# Altium schematic sheet INTERFACE.SchDoc(INTERFACE)
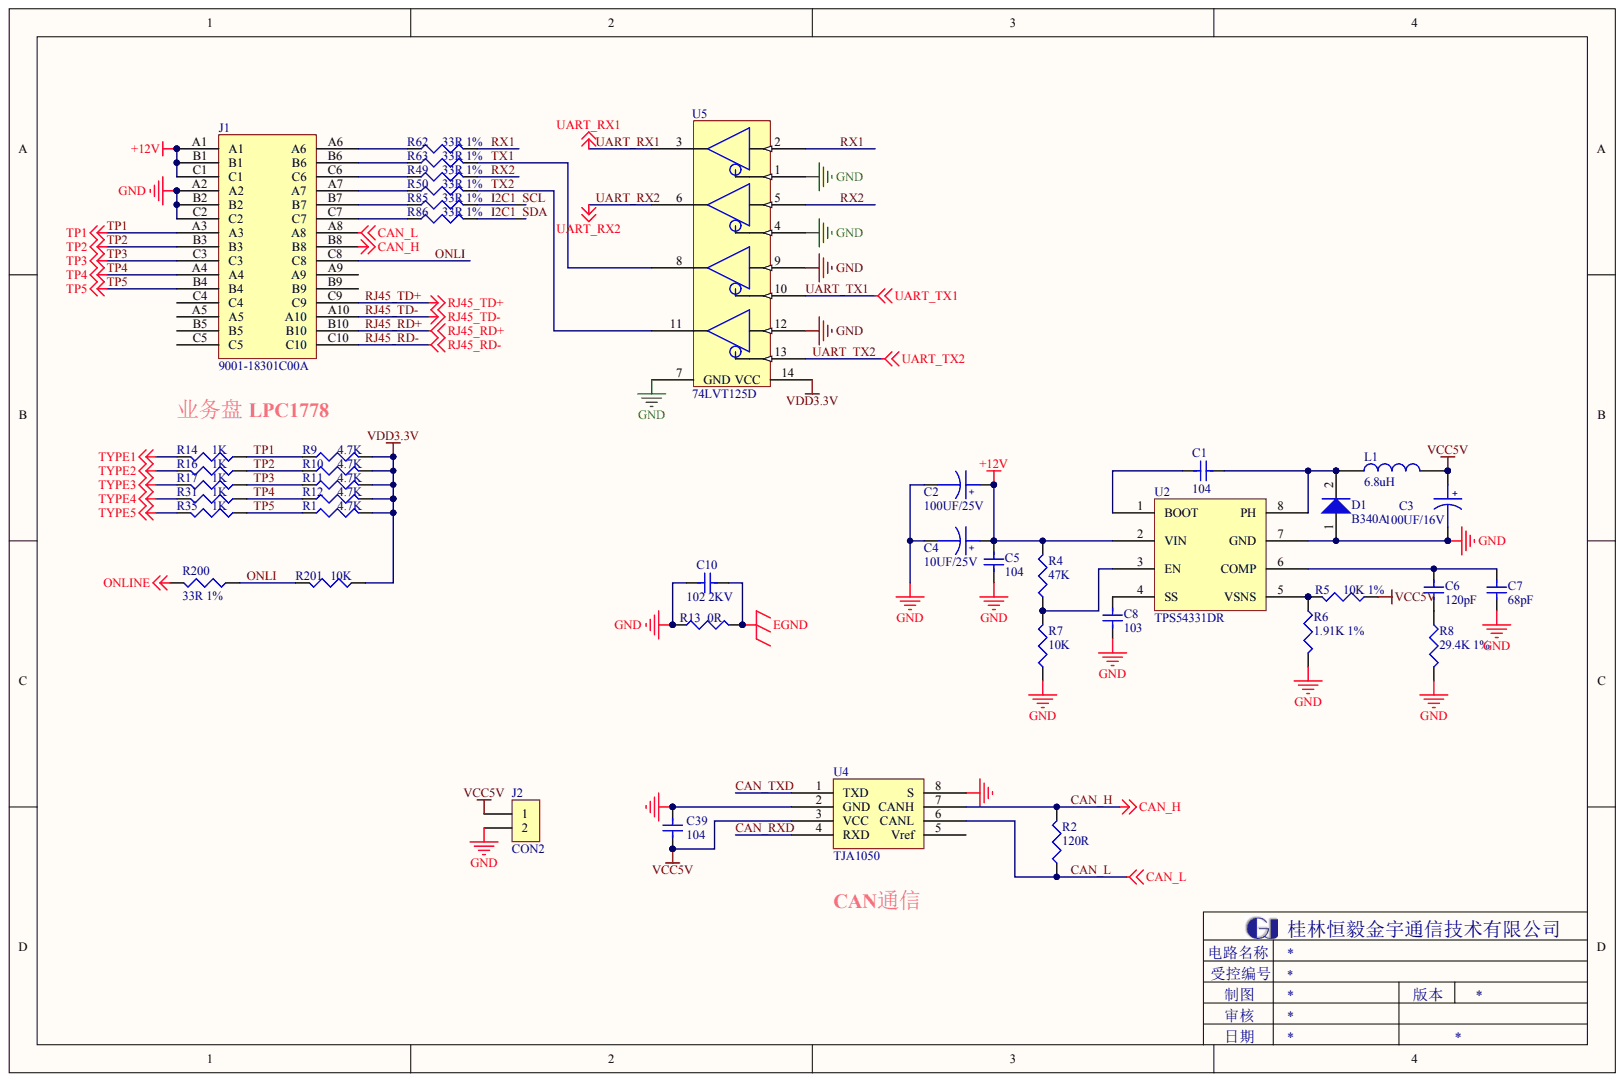
[diagram p0: INTERFACE.SchDoc]

## Parts
C1  104
C2  100UF/25V
C3  100UF/16V
C4  10UF/25V
C5  104
C6  120pF
C7  68pF
C8  103
C10  102 2KV
C39  104
D1  B340A
J1  9001-18301C00A
J2  CON2
L1  6.8uH
R1  4.7K
R2  120R
R4  47K
R5  10K 1%
R6  1.91K 1%
R7  10K
R8  29.4K 1%
R9  4.7K
R10  4.7K
R11  4.7K
R12  4.7K
R13  0R
R14  1K
R16  1K
R17  1K
R31  1K
R35  1K
R49  33R 1%
R50  33R 1%
R62  33R 1%
R63  33R 1%
R85  33R 1%
R86  33R 1%
R200  33R 1%
R201  10K
U2  TPS54331DR
U4  TJA1050
U5  74LVT125D

## Nets
+12V: C2.1 C4.1 C5.2 J1.A1 J1.B1 J1.C1 R4.2 U2.2(VIN)
EGND: C10.2 R13.2
GND: C2.2 C3.2 C4.2 C5.1 C7.1 C8.1 C10.1 C39.1 D1.1 J1.A2 J1.B2 J1.C2 J2.2 R6.2 R7.1 R8.2 R13.1 U2.7(GND) U4.2(GND) U4.8(S) U5.1 U5.4 U5.7(GND) U5.9 U5.12
ONLINE: R200.2
TYPE1: R14.2
TYPE2: R16.2
TYPE3: R17.2
TYPE4: R31.2
TYPE5: R35.2
VCC5V: C3.1 C39.2 J2.1 L1.2 R5.1 U4.3(VCC)
VDD3.3V: R1.2 R9.2 R10.2 R11.2 R12.2 R201.1 U5.14(VCC)
CAN_H: J1.B8 R2.2 U4.7(CANH)
CAN_L: J1.A8 R2.1 U4.6(CANL)
CAN_RXD: U4.4(RXD)
CAN_TXD: U4.1(TXD)
I2C1_SCL: R85.2
I2C1_SDA: R86.2
ONLI: J1.C8 R200.1 R201.2
RJ45_RD+: J1.B10
RJ45_RD-: J1.C10
RJ45_TD+: J1.C9
RJ45_TD-: J1.A10
RX1: R62.2 U5.2
RX2: R49.2 U5.5
TP1: J1.A3 R9.1 R14.1
TP2: J1.B3 R10.1 R16.1
TP3: J1.C3 R11.1 R17.1
TP4: J1.A4 R12.1 R31.1
TP5: J1.B4 R1.1 R35.1
TX1: R63.2 U5.8
TX2: R50.2 U5.11
UART_RX1: U5.3
UART_RX2: U5.6
UART_TX1: U5.10
UART_TX2: U5.13
NetC1_1: C1.1 D1.2 L1.1 U2.8(PH)
NetC1_2: C1.2 U2.1(BOOT)
NetC6_1: C6.1 R8.1
NetC6_2: C6.2 C7.2 U2.6(COMP)
NetC8_2: C8.2 U2.4(SS)
NetJ1_A6: J1.A6 R62.1
NetJ1_A7: J1.A7 R50.1
NetJ1_B6: J1.B6 R63.1
NetJ1_B7: J1.B7 R85.1
NetJ1_C6: J1.C6 R49.1
NetJ1_C7: J1.C7 R86.1
NetR4_1: R4.1 R7.2 U2.3(EN)
NetR5_2: R5.2 R6.1 U2.5(VSNS)

Not connected: J1 A5 A9 B5 B9 C4 C5; U4 5(Vref)
